# Altium schematic sheet Motor Control Bridge.SchDoc
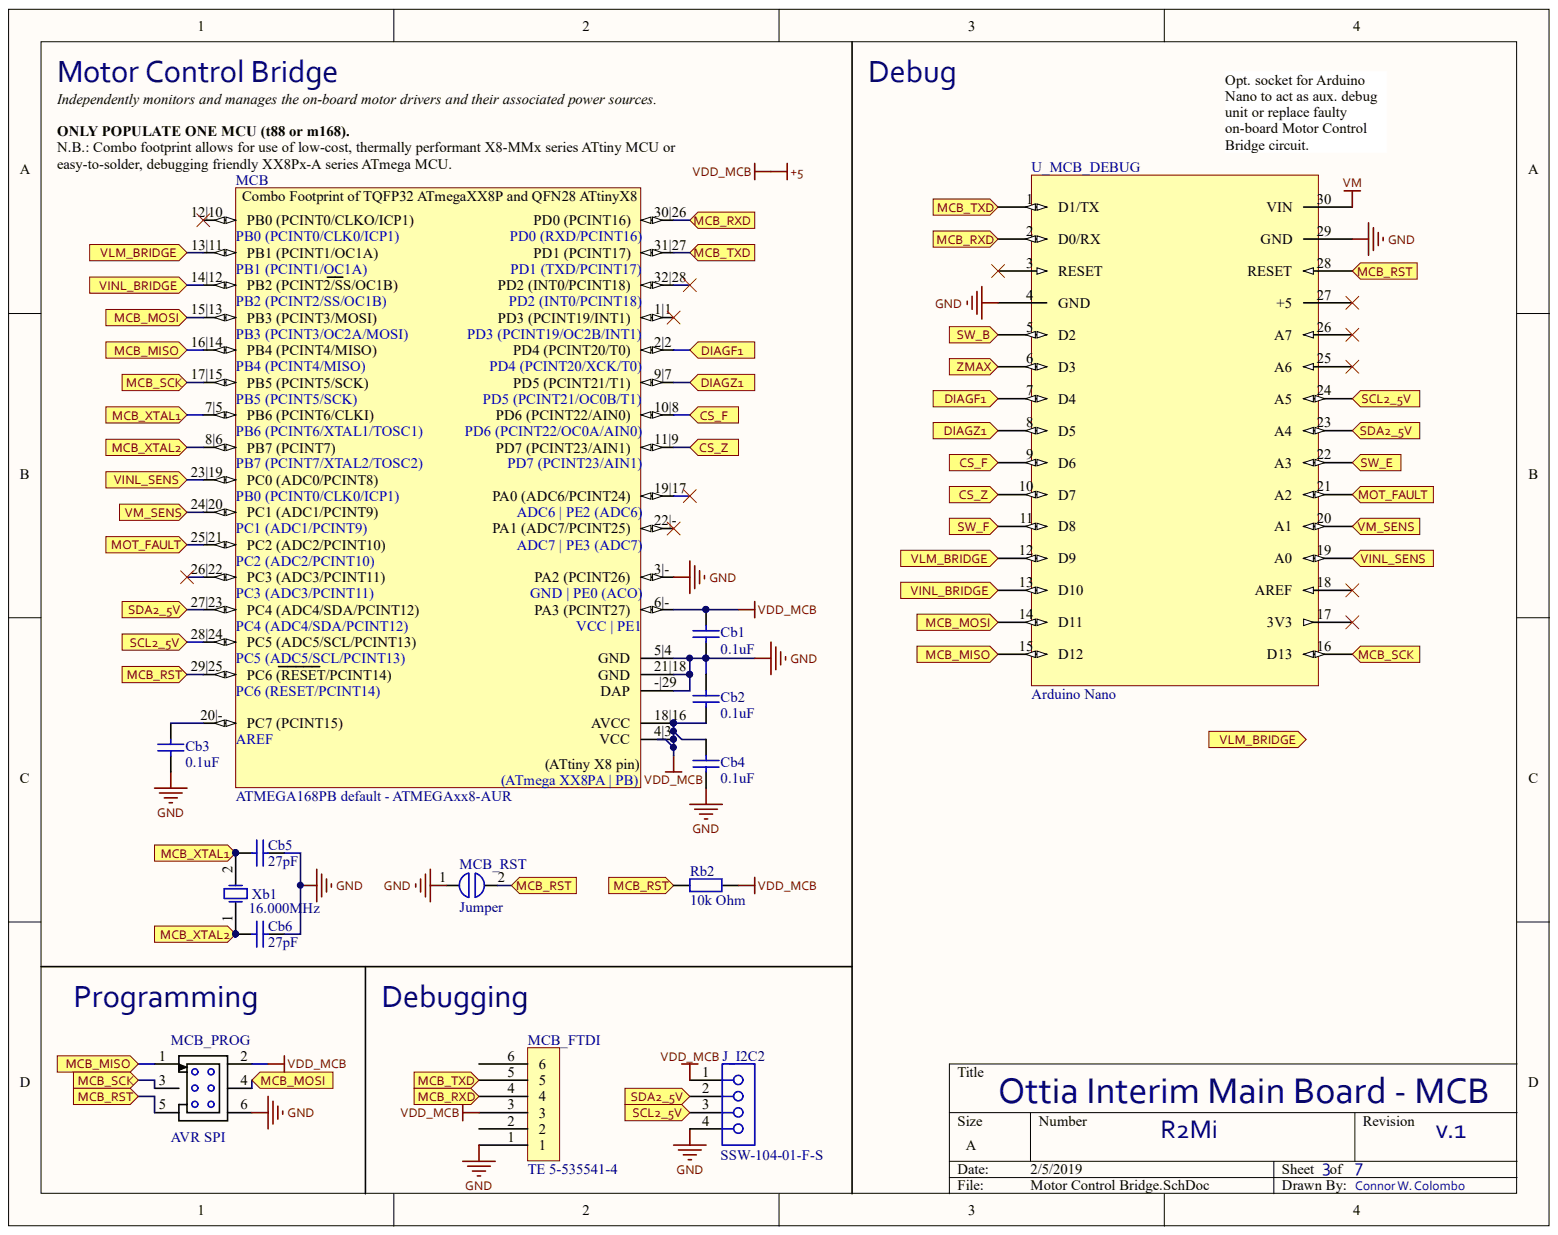
[diagram p0: Motor Control Bridge.SchDoc]

## Parts
Cb1  0.1uF  [PCB-eedgklwdvp799dppbzpc-1]
Cb2  0.1uF  [PCB-eedgklwdvp799dppbzpc-1]
Cb3  0.1uF  [PCB-eedgklwdvp799dppbzpc-1]
Cb4  0.1uF  [PCB-eedgklwdvp799dppbzpc-1]
Cb5  27pF  [CAPC1608X87X35NL15T15]
Cb6  27pF  [CAPC1608X87X35NL15T15]
J_I2C2  SSW-104-01-F-S  [SSW-104-02-G-S]
MCB  ATMEGA168PB default - ATMEGAxx8-AUR  Packaging: TQFP32 and QFN28  [PCB-0qwdt9ra15779qz1kxmr-1]
MCB_FTDI  TE 5-535541-4  [PCB-37tq4is5hft0i8ivfv75-1]
MCB_PROG  AVR SPI  [PCB-l6fbhhbmmdzifrvjozvs-1]
MCB_RST  Jumper  [PCB-6gvn9cty3r54iuqtdtvh-1]
Rb2  10k Ohm  [PCB-55aunbi2drca6iadvin9-1]
U_MCB_DEBUG  Arduino Nano  [Arduino-Minimal]
Xb1  16.000MHz  [PCB-fu593xkg4al34p0f0gbr-1]

## Ports
CS_F, CS_Z, DIAGF1, DIAGZ1, MCB_MISO, MCB_MOSI, MCB_RST, MCB_RXD, MCB_SCK, MCB_TXD, MCB_XTAL1, MCB_XTAL2, MOT_FAULT, SCL2_5V, SDA2_5V, SW_B, SW_E, SW_F, VINL_BRIDGE, VINL_SENS, VLM_BRIDGE, VM_SENS, ZMAX

## Nets
+5: Cb1.2 Cb2.1 Cb4.2 J_I2C2.1 MCB.4|3(VCC) MCB.6|-(PA3_(PCINT27)) MCB.18|16(AVCC) MCB_FTDI.3 MCB_PROG.2 Rb2.2
GND: Cb1.1 Cb2.2 Cb3.1 Cb4.1 Cb5.1 Cb6.1 J_I2C2.4 MCB.3|-(PA2_(PCINT26)) MCB.5|4(GND) MCB.21|18(GND) MCB.-|29(DAP) MCB_FTDI.1 MCB_PROG.6 MCB_RST.1 U_MCB_DEBUG.4(GND) U_MCB_DEBUG.29(GND)
VM: U_MCB_DEBUG.30(VIN)
CS_F: MCB.10|8(PD6_(PCINT22/AIN0)) U_MCB_DEBUG.9(D6) ; port CS_F
CS_Z: MCB.11|9(PD7_(PCINT23/AIN1)) U_MCB_DEBUG.10(D7) ; port CS_Z
DIAGF1: MCB.2|2(PD4_(PCINT20/T0)) U_MCB_DEBUG.7(D4) ; port DIAGF1
DIAGZ1: MCB.9|7(PD5_(PCINT21/T1)) U_MCB_DEBUG.8(D5) ; port DIAGZ1
MCB_MISO: MCB.16|14(PB4_(PCINT4/MISO)) MCB_PROG.1 U_MCB_DEBUG.15(D12) ; port MCB_MISO
MCB_MOSI: MCB.15|13(PB3_(PCINT3/MOSI)) MCB_PROG.4 U_MCB_DEBUG.14(D11) ; port MCB_MOSI
MCB_RST: MCB.29|25(PC6_(RESET/PCINT14)) MCB_PROG.5 MCB_RST.2 Rb2.1 U_MCB_DEBUG.28(RESET) ; port MCB_RST
MCB_RXD: MCB.30|26(PD0_(PCINT16)) MCB_FTDI.4 U_MCB_DEBUG.2(D0/RX) ; port MCB_RXD
MCB_SCK: MCB.17|15(PB5_(PCINT5/SCK)) MCB_PROG.3 U_MCB_DEBUG.16(D13) ; port MCB_SCK
MCB_TXD: MCB.31|27(PD1_(PCINT17)) MCB_FTDI.5 U_MCB_DEBUG.1(D1/TX) ; port MCB_TXD
MCB_XTAL1: Cb5.2 MCB.7|5(PB6_(PCINT6/CLKI)) Xb1.2 ; port MCB_XTAL1
MCB_XTAL2: Cb6.2 MCB.8|6(PB7_(PCINT7)) Xb1.1 ; port MCB_XTAL2
MOT_FAULT: MCB.25|21(PC2_(ADC2/PCINT10)) U_MCB_DEBUG.21(A2) ; port MOT_FAULT
SCL2_5V: J_I2C2.3 MCB.28|24(PC5_(ADC5/SCL/PCINT13)) U_MCB_DEBUG.24(A5) ; port SCL2_5V
SDA2_5V: J_I2C2.2 MCB.27|23(PC4_(ADC4/SDA/PCINT12)) U_MCB_DEBUG.23(A4) ; port SDA2_5V
SW_B: U_MCB_DEBUG.5(D2) ; port SW_B
SW_E: U_MCB_DEBUG.22(A3) ; port SW_E
SW_F: U_MCB_DEBUG.11(D8) ; port SW_F
VINL_BRIDGE: MCB.14|12(PB2_(PCINT2/SS/OC1B)) U_MCB_DEBUG.13(D10) ; port VINL_BRIDGE
VINL_SENS: MCB.23|19(PC0_(ADC0/PCINT8)) U_MCB_DEBUG.19(A0) ; port VINL_SENS
VLM_BRIDGE: MCB.13|11(PB1_(PCINT1/OC1A)) U_MCB_DEBUG.12(D9) ; port VLM_BRIDGE
VM_SENS: MCB.24|20(PC1_(ADC1/PCINT9)) U_MCB_DEBUG.20(A1) ; port VM_SENS
ZMAX: U_MCB_DEBUG.6(D3) ; port ZMAX
NetCb3_2: Cb3.2 MCB.20|-(PC7_(PCINT15))

Not connected: MCB 1|1(PD3_(PCINT19/INT1)) 12|10(PB0_(PCINT0/CLKO/ICP1)) 19|17(PA0_(ADC6/PCINT24)) 22|-(PA1_(ADC7/PCINT25)) 26|22(PC3_(ADC3/PCINT11)) 32|28(PD2_(INT0/PCINT18)); MCB_FTDI 2 6; U_MCB_DEBUG 3(RESET) 17(3V3) 18(AREF) 25(A6) 26(A7) 27(+5)

## Library data of the parts
Cb1-Cb4 = CMP-48bd81bce6dc977a-1: NFM Series 0603 0.1 uF 2 A 16 V SMT Chip Capacitor Type EMI Filter; Manufacturer Part Number: NFM18PC104R1C3D; Capacitance: 100 nF; Current Rating: 2.00 A; Lead-Free Status: Lead Free; Shielding: No; Voltage Rating (DC): 16.0 V; Case/Package: 0603; Component Kind: Standard; Library Name: FavoritesLibrary; Mounting Style: Surface Mount; OctopartID: 48bd81bce6dc977a; Octopart URL: https://octopart.com/nfm18pc104r1c3d-murata-756487; Packaging: Cut Tape (CT); REACH SVHC Compliance: No SVHC; RoHS: Compliant; Size-Length: 1.60 mm; Size-Width: 800 µm
Cb5 Cb6 = C0603C270J3GACTU: Cap Ceramic 27pF 25V C0G 5% SMD 0603 125C Paper T/R; Capacitance: 2.7e-11 F; Lead-Free Status: Lead Free; Voltage Rating (DC): 25.0 V; Capacitance Tolerance: ±5%; Case/Package: 0603; Component Kind: Standard; Dielectric Material: Ceramic; Library Name: Octopart library; Manufacturer Part Number: C0603C270J3GACTU; Mounting Style: Surface Mount; OctopartID: 6224aba532f6bde3; Octopart Url: https://octopart.com/c0603c270j3gactu-kemet-9139636; RoHS: Compliant
J_I2C2 = CMP-2f26e6288f97f0e1-2: Conn Socket Strip SKT 4 POS 2.54mm Solder ST Thru-Hole; Contact Resistance: 10.0 mΩ; Gender: Female; Lead-Free Status: Lead Free; Number of Contacts: 4; Number of Mating Cycles: 100; Color: Black; Component Kind: Standard; Contact Material: Phosphor Bronze; Contact Plating: Gold; Housing Color: Black; Housing Material: Plastic; Library Name: CommunityVault; Manufacturer Part Number: SSW-104-02-G-S; Mounting Style: Through Hole; Number of Positions: 4; Number of Rows: 1; Octopart URL: http://octopart.com/ssw-104-02-g-s-samtec-296407; Packaging: Tube; Pin Pitch: 2.54 mm; REACH SVHC Compliance: No SVHC; RoHS: Yes; Size-Height: 8.51 mm; Size-Length: 10.7 mm; Size-Width: 2.41 mm
MCB = CMP-kmpmc5k2jkrzzjs0remh-2: Combo footprint of MMx (TQFP32) X8 Series ATtiny MCU and PA or PB Ax XX8P Series ATmega MCU.; Manufacturer Part Number: ATMEGA168PB-AUR; Clock Speed: 20.0 MHz; Lead-Free Status: Lead Free; Component Kind: Standard; CustomComponentID: kmpmc5k2jkrzzjs0remh; Library Name: FavoritesLibrary; Mounting Style: Surface Mount; Octopart URL: https://octopart.com/atmega168pb-aur-microchip-77759991; Octopart URL - ATmega168PB: https://octopart.com/atmega168pb-aur-microchip-77759991; Octopart URL -ATtiny88: https://octopart.com/attiny88-mmu-atmel-42521759; RoHS: Compliant
MCB_FTDI = 5-535541-4: AMPMODU MOD IV 6 Position Single Row 2.54 mm Pitch Vertical Receptacle Assembly; ELV Compliant: Compliant; Gender: Female; Lead-Free Status: Lead Free; Number of Contacts: 6; Pin Pitch: 0.00254 m; Component Kind: Standard; Contact Material: Phosphor Bronze; Contact Plating: Gold, Tin, Tin over Nickel; Flammability Rating: UL 94 V-0; Housing Color: Black; Housing Material: Polyester; Library Name: Octopart library; Lifecycle Status: Active; Manufacturer Part Number: 5-535541-4; Mounting Style: Through Hole; Number of Positions: 6; Number of Rows: 1; OctopartID: c7800cd018a91847; Octopart URL: http://octopart.com/5-535541-4-te+connectivity-39516751; Orientation: Vertical; Packaging: Tube; RoHS: Compliant; Size-Height: 0.00673 m; Size-Length: 0.01524 m; Size-Width: 0.00356 m
MCB_PROG = CMP-649956-8: CONN HEADER 6POS DUAL VERT PCB (FCI 75869-131LF); Gender: Male; Lead-Free Status: Lead Free; Number of Contacts: 6; Component Kind: Standard; Contact Material: Phosphor Bronze; Contact Plating: Gold; Library Name: CommunityVault; OctopartID: 9939526fc2f646fe; Octopart Url: https://octopart.com/75869-131lf-fci-39616169; REACH SVHC Compliance: No SVHC; RoHS: Compliant
MCB_RST = Solder Jumper-3: PCB Solder Jumper; CustomComponentID: 6gvn9cty3r54iuqtdtvh; Component Kind: Standard; Library Name: FavoritesLibrary; tYPE: Jumper
Rb2 = CMP-494d8056daa7968a-16: Res Thick Film 1.6 x 0.8 mm 10K Ohm 5% 0.1W(1/10W) 100ppm/ C Molded SMD Paper T/R; Component Kind: Standard; Library Name: CommunityVault
U_MCB_DEBUG = CMP-f1bd39841b322e7a-40: Nano 3.0 Atmel Atmega 328 MCU board; Number of Bits: 8; Component Kind: Standard; Library Name: CommunityVault; OctopartID: f1bd39841b322e7a; Octopart URL: http://octopart.com/a000005-arduino-20172777; REACH SVHC Compliance: No SVHC; RoHS: Compliant
Xb1 = ABLS-16.000MHZ-B4-T: Crystal 16MHz ??30ppm (Tol) ??50ppm (Stability) 18pF FUND 40Ohm 2-Pin HC-49/US SMD T/R; Lead-Free Status: Lead Free; Load Capacitance: 18.0 pF; Component Kind: Standard; Library Name: Octopart library; Manufacturer Part Number: ABLS-16.000MHZ-B4-T; Mounting Style: Surface Mount; OctopartID: 1760df762b07c8a7; Operating Temperature: 70.0 °C (max); Packaging: Reel; RoHS: Compliant
